# Altium schematic sheet 10_Power_LDOO.SchDoc(10_Power_LDOO)
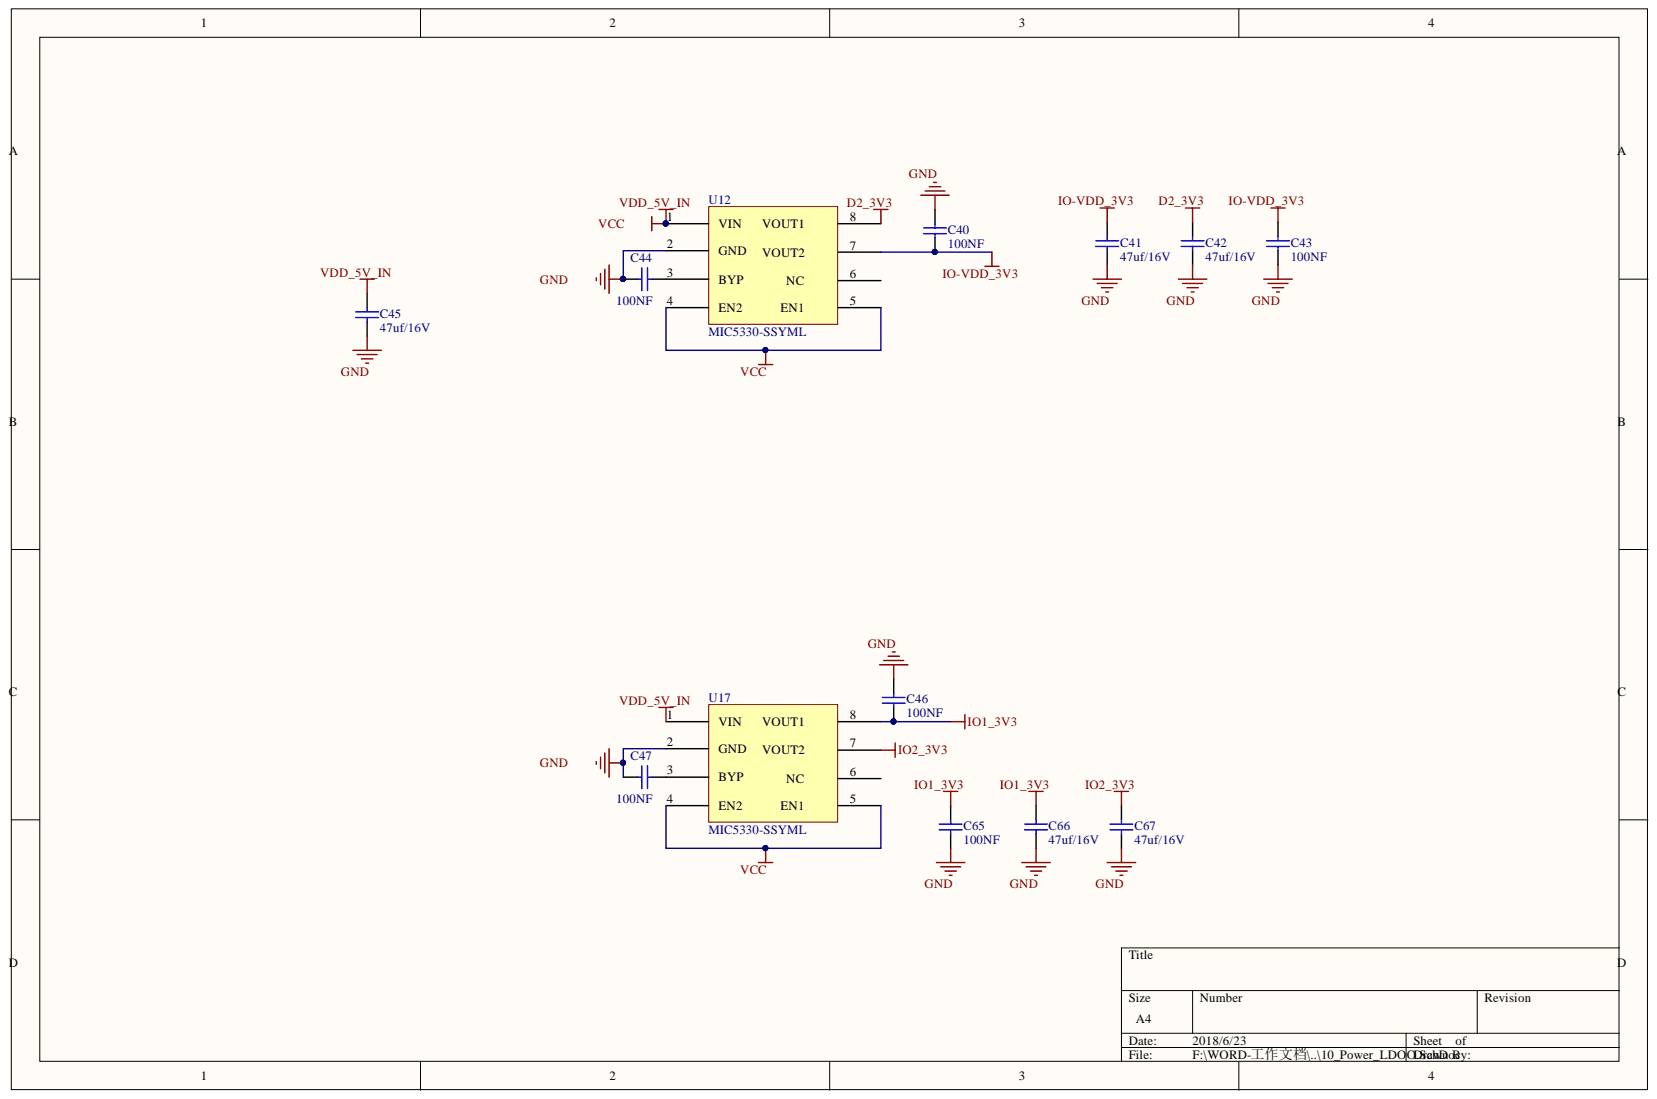
[diagram p0: 10_Power_LDOO.SchDoc]

## Parts
C40  100NF  [0402CAP]
C41  47uf/16V  [0805CAP]
C42  47uf/16V  [0805CAP]
C43  100NF  [0402CAP]
C44  100NF  [0402CAP]
C45  47uf/16V  [0805CAP]
C46  100NF  [0402CAP]
C47  100NF  [0402CAP]
C65  100NF  [0402CAP]
C66  47uf/16V  [0805CAP]
C67  47uf/16V  [0805CAP]
U12  MIC5330-SSYML  [MIC5530]
U17  MIC5330-SSYML  [MIC5530]

## Nets
D2_3V3: C42.1 U12.8
GND: C40.2 C41.2 C42.2 C43.1 C44.2 C45.2 C46.2 C47.2 C65.1 C66.2 C67.2 U12.2(GND) U17.2(GND)
IO1_3V3: C46.1 C65.2 C66.1 U17.8
IO2_3V3: C67.1 U17.7
IO-VDD_3V3: C40.1 C41.1 C43.2 U12.7
VCC: C45.1 U12.1(VIN) U12.4(EN2) U12.5 U17.1(VIN) U17.4(EN2) U17.5
NetC44_1: C44.1 U12.3(BYP)
NetC47_1: C47.1 U17.3(BYP)

Not connected: U12 6; U17 6

## Library data of the parts
C40 C44 C46 C47 = Cap: Value: 100pF; Component Kind: Standard; LatestRevisionDate: 17-Jul-2002; LatestRevisionNote: Re-released for DXP Platform.; Library Name: Miscellaneous Devices.IntLib; PackageDescription: Radial Cap, Thru-Hole; 2 Leads; 0.3 in Pin Spacing; PackageReference: RAD-0.3; Published: 8-Jun-2000; Signal Integrity: Cap; Simulation: CAP; Publisher: Altium Limited
C41 C42 C45 C66 C67 = Cap: Capacitor; Component Kind: Standard; Library Name: Miscellaneous Devices.IntLib; Signal Integrity: Cap; Simulation: CAP
C43 C65 = Cap: Component Kind: Standard; Library Name: Miscellaneous Devices.IntLib; Signal Integrity: Cap; Simulation: CAP
U12 U17 = MIC5530: Component Kind: Standard; Library Name: hack.SchLib
